# Altium schematic sheet Power_circuit.SchDoc
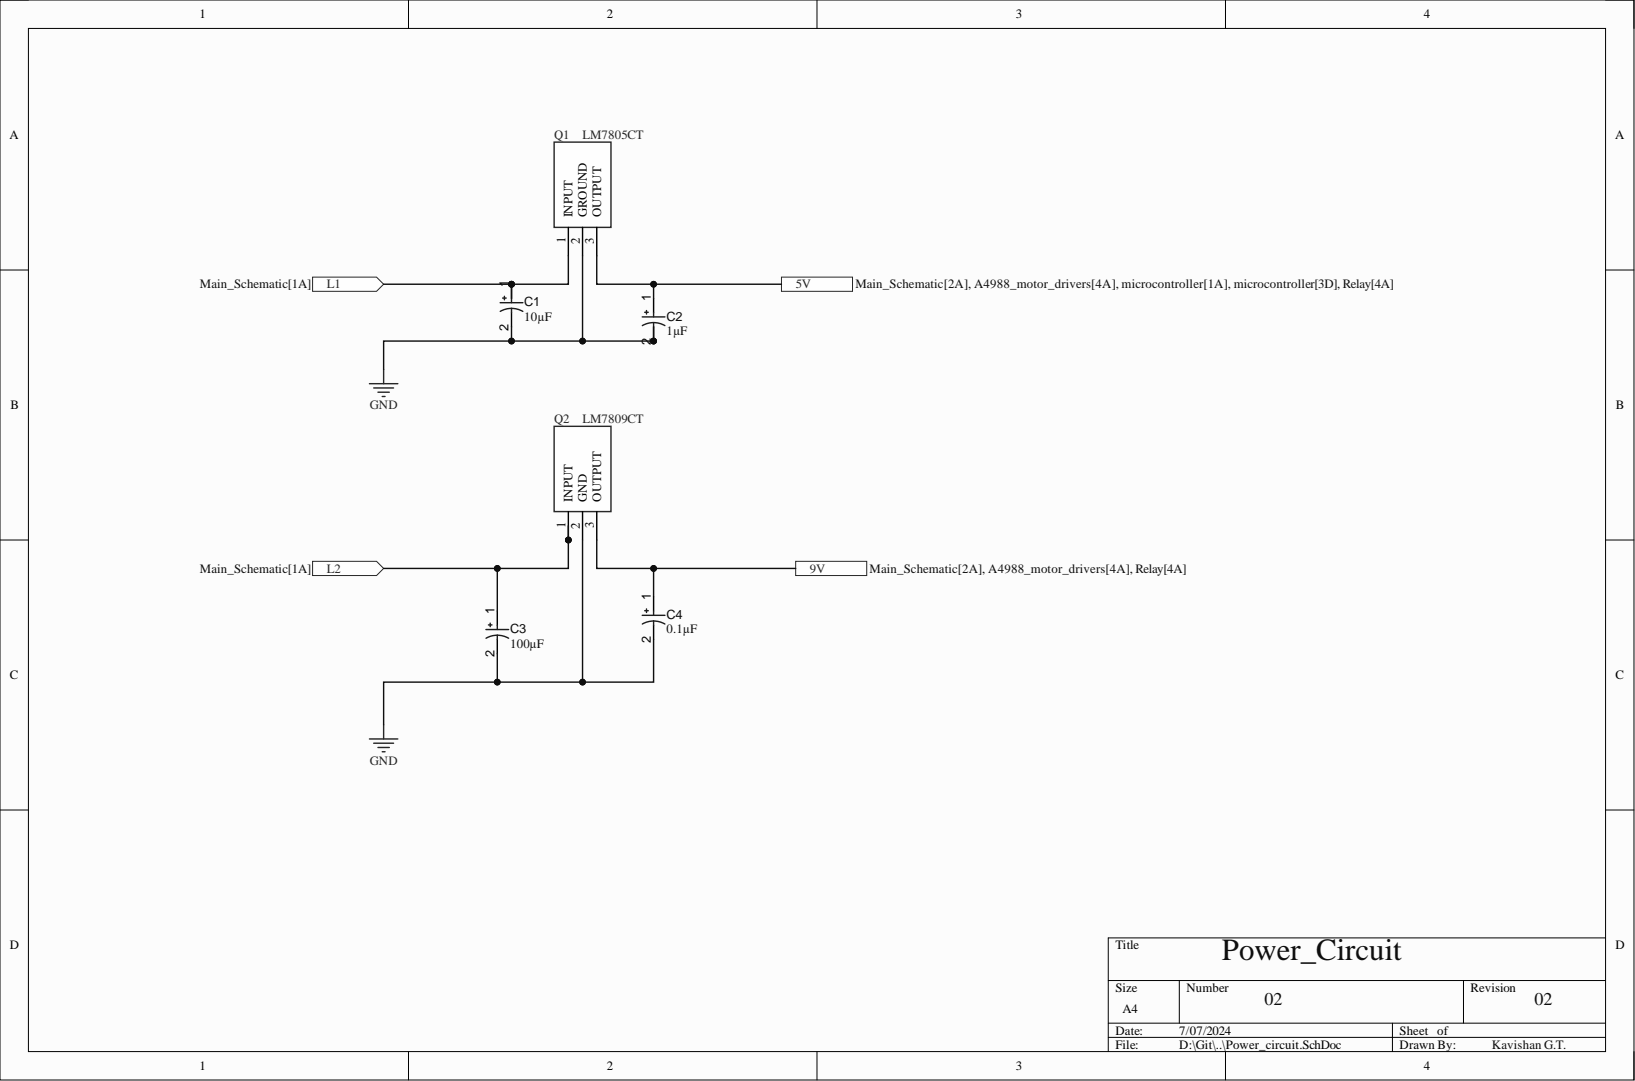
[diagram p0: Power_circuit.SchDoc]

## Parts
C1  value 10µF  [CAPPR55-200-1100X500X1200]  (hidden comment: ECA1HM100)
C2  value 1µF  [CAPPR55-200-1100X500X1200]  (hidden comment: ECA1HM100)
C3  value 100µF  [CAPPR55-200-1100X500X1200]  (hidden comment: ECA1HM100)
C4  value 0.1µF  [CAPPR55-200-1100X500X1200]  (hidden comment: ECA1HM100)
Q1  LM7805CT  [TO254P470X1028X1955-3P]
Q2  LM7809CT  [TO254P470X1016X2019-3P]

## Ports
5V, 9V, L1, L2

## Nets
GND: C1.2 C2.2 C3.2 C4.2 Q1.2 Q2.2
5V: C2.1 Q1.3 ; port 5V
9V: C4.1 Q2.3 ; port 9V
L1: C1.1 Q1.1 ; port L1
L2: C3.1 Q2.1 ; port L2

## Library data of the parts
C1-C4 = CMP-001-00025-7: Aluminum Electrolytic Capacitor, 10 uF, +/- 20%, 50 V, -40 to 85 degC, 2-Pin THD, RoHS, Bulk; Manufacturer: Panasonic; Ripple Current: 65mA; Voltage Rating: 50V; Capacitor Type: Electrolytic; Case/Package: Radial; Component Kind: Standard; Datasheet URL: https://industrial.panasonic.com/cdbs/www-data/pdf/RDF0000/ABA0000C1218.pdf; Datasheet Version: Rev. 05, 10/2016; Diameter: 5mm; Lead Pitch: 2mm; Manufacturer URL: http://www.panasonic.com/; Max Operating Temperature: 85°C; Min Operating Temperature: -40°C; Mounting Technology: TH; Package Description: 2-Pin Radial Lead, Dia 5 mm, Height 12 mm; Package Quantity: 200; Package Reference: CAPPR55-200-1100X500X1200; Packaging: Bulk; Pins: 2; Ripple Current (AC): 65mA; RoHS Compliant: True; Tolerance: 20%; Library Name: University of Moratuwa
Q1 = LM7805CT: Integrated Circuit; Manufacturer_Name: Texas Instruments; Arrow Part Number: LM7805CT; Arrow Price/Stock: https://www.arrow.com/en/products/lm7805ct/texas-instruments?region=europe; Component Kind: Standard; Datasheet Link: http://www.ti.com/lit/ds/symlink/lm340.pdf; Height: 4.7mm; Manufacturer_Part_Number: LM7805CT; Mouser Part Number: 926-LM7805CT; Mouser Price/Stock: https://www.mouser.co.uk/ProductDetail/Texas-Instruments/LM7805CT?qs=QbsRYf82W3EaqXeMDVwIfQ%3D%3D
Q2 = LM7809CT: Power Supply; Manufacturer_Name: onsemi; Arrow Part Number: LM7809CT; Arrow Price/Stock: https://www.arrow.com/en/products/lm7809ct/on-semiconductor?region=europe; Component Kind: Standard; Datasheet Link: https://mouser.componentsearchengine.com/Datasheets/2/LM7809CT.pdf; Height: 4.7mm; Manufacturer_Part_Number: LM7809CT; Mouser Part Number: 512-LM7809CT; Mouser Price/Stock: https://www.mouser.co.uk/ProductDetail/ON-Semiconductor-Fairchild/LM7809CT?qs=VOMQJJE%252BBNmmTueoZonUjQ%3D%3D
